# Altium schematic sheet Chassis-Rx-PCB(STM32).SchDoc
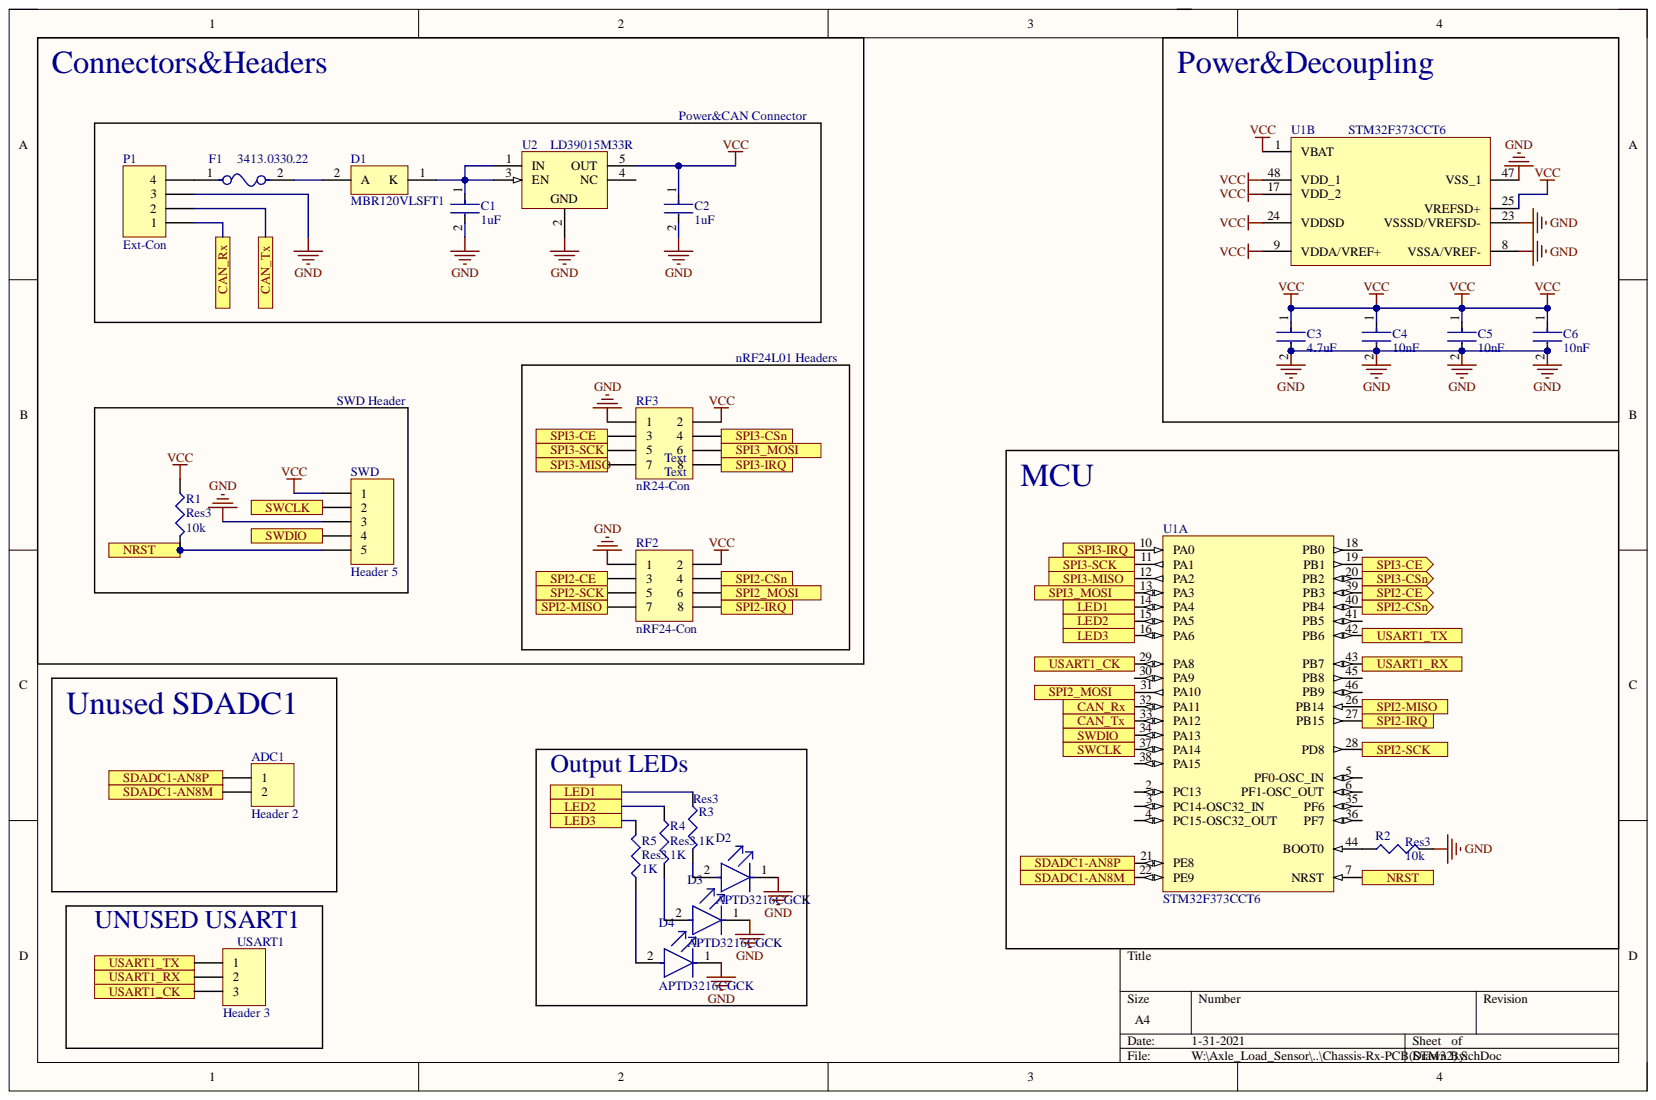
[diagram p0: Chassis-Rx-PCB(STM32).SchDoc]

## Parts
ADC1  Header 2  [HDR1X2]
C1  1uF  [FP-C0805C-DN-MFG]
C2  1uF  [FP-C0805C-DN-MFG]
C3  4.7uF  [FP-C0805C-DN-MFG]
C4  10nF  [FP-C0805C-DN-MFG]
C5  10nF  [FP-C0805C-DN-MFG]
C6  10nF  [FP-C0805C-DN-MFG]
D1  MBR120VLSFT1  [ONSC-SOD-123FL-2-498-01_V]
D2  APTD3216CGCK  [FP-APTD3216CGCK-MFG]
D3  APTD3216CGCK  [FP-APTD3216CGCK-MFG]
D4  APTD3216CGCK  [FP-APTD3216CGCK-MFG]
F1  3413.0330.22  [FP-3413_0330_22-MFG]
P1  Ext-Con  [HDR1X4H]
R1  Res3  value 10k  [J1-0603]
R2  Res3  value 10k  [J1-0603]
R3  Res3  value 1K  [J1-0603]
R4  Res3  value 1K  [J1-0603]
R5  Res3  value 1K  [J1-0603]
RF2  nRF24-Con  [HDR2X4]
RF3  nR24-Con  [HDR2X4]
SWD  Header 5  [HDR1X5]
U1  STM32F373CCT6  [STM-LQFP48_L]  (parts A,B)
U2  LD39015M33R  [SOT23-5L_M]
USART1  Header 3  [HDR1X3]

## Ports
CAN_Rx, CAN_Tx, LED1, LED2, LED3, NRST, SDADC1-AN8M, SDADC1-AN8P, SPI2-CE, SPI2-CSn, SPI2-IRQ, SPI2-MISO, SPI2-SCK, SPI2_MOSI, SPI3-CE, SPI3-CSn, SPI3-IRQ, SPI3-MISO, SPI3-SCK, SPI3_MOSI, SWCLK, SWDIO, USART1_CK, USART1_RX, USART1_TX

## Nets
GND: C1.2 C2.2 C3.2 C4.2 C5.2 C6.2 D2.1 D3.1 D4.1 P1.3 R2.2 RF2.1 RF3.1 SWD.3 U1.8(VSSA/VREF-) U1.23(VSSSD/VREFSD-) U1.47(VSS_1) U2.2
VCC: C2.1 C3.1 C4.1 C5.1 C6.1 R1.1 RF2.2 RF3.2 SWD.1 U1.1(VBAT) U1.9(VDDA/VREF+) U1.17(VDD_2) U1.24(VDDSD) U1.25(VREFSD+) U1.48(VDD_1) U2.5(OUT)
CAN_Rx: P1.1 U1.32(PA11) ; port CAN_Rx
CAN_Tx: P1.2 U1.33(PA12) ; port CAN_Tx
LED1: R3.1 U1.14(PA4) ; port LED1
LED2: R4.1 U1.15(PA5) ; port LED2
LED3: R5.2 U1.16(PA6) ; port LED3
NRST: R1.2 SWD.5 U1.7(NRST) ; port NRST
SDADC1-AN8M: ADC1.2 U1.22(PE9) ; port SDADC1-AN8M
SDADC1-AN8P: ADC1.1 U1.21(PE8) ; port SDADC1-AN8P
SPI2-CE: RF2.3 U1.39(PB3) ; port SPI2-CE
SPI2-CSn: RF2.4 U1.40(PB4) ; port SPI2-CSn
SPI2-IRQ: RF2.8 U1.27(PB15) ; port SPI2-IRQ
SPI2-MISO: RF2.7 U1.26(PB14) ; port SPI2-MISO
SPI2-SCK: RF2.5 U1.28(PD8) ; port SPI2-SCK
SPI2_MOSI: RF2.6 U1.31(PA10) ; port SPI2_MOSI
SPI3-CE: RF3.3 U1.19(PB1) ; port SPI3-CE
SPI3-CSn: RF3.4 U1.20(PB2) ; port SPI3-CSn
SPI3-IRQ: RF3.8 U1.10(PA0) ; port SPI3-IRQ
SPI3-MISO: RF3.7 U1.12(PA2) ; port SPI3-MISO
SPI3-SCK: RF3.5 U1.11(PA1) ; port SPI3-SCK
SPI3_MOSI: RF3.6 U1.13(PA3) ; port SPI3_MOSI
SWCLK: SWD.2 U1.37(PA14) ; port SWCLK
SWDIO: SWD.4 U1.34(PA13) ; port SWDIO
USART1_CK: U1.29(PA8) USART1.3 ; port USART1_CK
USART1_RX: U1.43(PB7) USART1.2 ; port USART1_RX
USART1_TX: U1.42(PB6) USART1.1 ; port USART1_TX
NetC1_1: C1.1 D1.1 U2.1(IN) U2.3(EN)
NetD1_2: D1.2 F1.2
NetD2_2: D2.2 R3.2
NetD3_2: D3.2 R4.2
NetD4_2: D4.2 R5.1
NetF1_1: F1.1 P1.4
NetR2_1: R2.1 U1.44(BOOT0)

Not connected: U1 2(PC13) 3(PC14-OSC32_IN) 4(PC15-OSC32_OUT) 5(PF0-OSC_IN) 6(PF1-OSC_OUT) 18(PB0) 30(PA9) 35(PF6) 36(PF7) 38(PA15) 41(PB5) 45(PB8) 46(PB9); U2 4(NC)

## Library data of the parts
ADC1 = Header 2: Header, 2-Pin; Component Kind: Standard; LatestRevisionDate: 17-Jul-2002; LatestRevisionNote: Re-released for DXP Platform.; Library Name: Miscellaneous Connectors.IntLib; Signal Integrity: Connector; Publisher: Altium Limited
C1-C6 = CMP-1036-04552-2: CAP CER 0.22UF 50V X7R 0805; Manufacturer: KEMET; Manufacturer Part Number: C0805C224K5RACTU; Capacitance: 0.22 μF; Material: Ceramic; Temperature Coefficient: 30ppm/°C; Tolerance: 0.1; Value: 0.22 μF; Automotive: No; Category: Cap; Component Kind: Standard; ComponentLink2Description: Datasheet; ComponentLink2URL: https://content.kemet.com/datasheets/KEM_C1003_C0G_SMD.pdf; Device Class L1: Passive Components; Device Class L2: Capacitors; Device Class L3: Ceramic Capacitors; Digikey Description: CAP CER 0.22UF 50V X7R 0805; Height: 0.88mm; Ipc Land Pattern Name: CAPC200125X78; Lead Free: Yes; Library Name: Altium Content Vault; Mouser Description: CAP CER 0.22UF 50V X7R 0805; Octopart: https://octopart.com/c0805c224k5ractu-kemet-144670; Package: C0805C-DN; RoHS: TRUE; Temperature: -55°C to +125°C; Temperature Characteristic: X7R; Temperature Range High: +125°C; Temperature Range Low: -55°C; Voltage Rating: 50 V
D1 = CMP-1055-00448-1: Schottky Power Rectifier, 2-Pin SOD-123, Tape and Reel; Manufacturer: On Semiconductor; Configuration: Single; IFSM Max (A): 45; Component Kind: Standard; ComponentLink1Description: Manufacturer URL; ComponentLink1URL: http://www.onsemi.com/; ComponentLink2Description: Datasheet; ComponentLink2URL: http://www.onsemi.cn/pub_link/Collateral/MBR120VLSFT1-D.PDF; ComponentLink3Description: Package Specification; ComponentLink3URL: http://www.onsemi.cn/pub_link/Collateral/MBR120VLSFT1-D.PDF; DatasheetVersion: Rev. 2; Library Name: Altium Content Vault; Mounting Technology: Surface Mount; PackageDescription: 2-Pin SOD, Body 1.65 x 2.7 mm; PackageReference: SOD-123FL-2-498-01; PackageVersion: Rev. A; Packing: Tape and Reel; PartNumber: MBR120VLSFT1; RoHS: FALSE
D2-D4 = CMP-2000-05792-2: LED GREEN CLEAR 2SMD; Dominant Wavelength: 570nm; Forward Current: 20mA; Forward Voltage: 2.1V; Luminous Intensity: 300mcd; Number of LEDs: 1; Viewing Angle: 50°; Case/Package: 1206; Case Code (Imperial): 1206; Case Code (Metric): 3216; Color: Green; Component Kind: Standard; Height: 1.8mm; Illumination Color: Green; Lead Free: Lead Free; Length: 3.2mm; Lens Color: Clear; Lens Style: Clear; Library Name: Altium Content Vault; Max Operating Temperature: 85°C; Min Operating Temperature: -40°C; Mount: Surface Mount; Number of Pins: 2; Package Quantity: 2000; Packaging: Tape and Reel; Test Current: 20mA; Width: 1.6mm
F1 = CMP-26293-000001-1: FUSE BOARD MNT 15A 32VAC 63VDC; Manufacturer: Schurter Inc; Fuse Blow Speed: Slow; Fuse I2T: 65A2Sec; Fuse Interrupt Dc Current: 100A; Fuse Voltage Drop: 57mV; Max Fuse Ac Voltage: 32V; Max Fuse Dc Voltage: 63V; Resistance: 3.5mΩ; Value: 3.5mΩ; Ambient Temperature Range High: +90°C; Ambient Temperature Range Low: -55°C; Automotive: No; Category: Fuse; Component Kind: Standard; ComponentLink2Description: Datasheet; ComponentLink2URL: https://us.schurter.com/en/datasheet/typ_UST_1206.pdf; Device Class L1: Circuit Protection; Device Class L2: Fuses; Device Class L3: unset; Digikey Description: FUSE BOARD MNT 15A 32VAC 63VDC; Fuse Current: 15A; Height: 0.6mm; Ipc Land Pattern Name: CAPC320160X60; Lead Free: Yes; Library Name: Altium Content Vault; Manufacturer Part Number: 3413.0330.22; Mouser Description: Surface Mount Fuses UST 1206 FUSE 15A F; Octopart: https://octopart.com/3413.0330.22-schurter-8400836; Package: 0; RoHS: TRUE; Voltage Rating: 63V
P1 = Header 4H: Header, 4-Pin, Right Angle; Component Kind: Standard; LatestRevisionDate: 17-Jul-2002; LatestRevisionNote: Re-released for DXP Platform.; Library Name: Miscellaneous Connectors.IntLib; Signal Integrity: Connector; Publisher: Altium Limited
R1-R5 = Res3: Resistor; Component Kind: Standard; LatestRevisionDate: 29-May-2009; LatestRevisionNote: IPC-7351 Footprint Added.; Library Name: Miscellaneous Devices.IntLib; PackageDescription: Chip Resistor; PackageReference: J1-0603; Published: 8-Jun-2000; Signal Integrity: Res; Simulation: RESISTOR; Publisher: Altium Limited
RF2 RF3 = Header 4X2: Header, 4-Pin, Dual row; Component Kind: Standard; LatestRevisionDate: 17-Jul-2002; LatestRevisionNote: Re-released for DXP Platform.; Library Name: Miscellaneous Connectors.IntLib; Signal Integrity: Connector; Publisher: Altium Limited
SWD = Header 5: Header, 5-Pin; Component Kind: Standard; LatestRevisionDate: 17-Jul-2002; LatestRevisionNote: Re-released for DXP Platform.; Library Name: Miscellaneous Connectors.IntLib; Signal Integrity: Connector; Publisher: Altium Limited
U1 = CMP-0572-00021-2: ARM Cortex-M4 32-bit MCU, 256 KB Flash, 32 KB Internal RAM, 36 I/Os, 48-pin LQFP, -40 to 85 degC, Tray; FLASH Size (Prog) (kB): 256; I/Os (High Current): 36; Internal RAM Size (kB): 32; Operating Frequency(F) (Processor speed) (MHz): 72; Supply Voltage(Vcc) min (V): 2; Component Kind: Standard; ComponentLink1Description: Manufacturer URL; ComponentLink1URL: http://www.st.com/web/en/home.html; ComponentLink2Description: Datasheet; ComponentLink2URL: http://www.st.com/st-web-ui/static/active/en/resource/technical/document/datasheet/DM00046749.pdf; ComponentLink3Description: Package Specification; ComponentLink3URL: http://www.st.com/st-web-ui/static/active/en/resource/technical/document/datasheet/DM00058181.pdf; Core: ARM Cortex-M4; DatasheetVersion: Rev. 3; Library Name: Altium Content Vault; Mounting Technology: Surface Mount; PackageDescription: 48-Pin Low Profile Quad Flat Package, 7 x 7 mm Body, 0.5 mm Pitch; PackageReference: LQFP48; PackageVersion: Rev. 6, 06/2013; Packing: Tray; PartNumber: STM32F373CCT6
U2 = CMP-0244-00351-1: 150 mA Low Quiescent Current Low Noise Voltage Regulator, 3.3V, 5-Pin SOT-23, Tape and Reel; Component Kind: Standard; ComponentLink1Description: Manufacturer URL; ComponentLink1URL: http://www.st.com; ComponentLink2Description: Datasheet; ComponentLink2URL: http://www.st.com/internet/com/TECHNICAL_RESOURCES/TECHNICAL_LITERATURE/DATASHEET/CD00173477.pdf; DatasheetVersion: 1/02/2009; Library Name: Altium Content Vault; PackageDescription: 5-Pin SOT-23 Package, 2.9 x 1.6 mm Body, 0.95 mm Pitch, 2.8 mm Lead Span; PackageReference: SOT23-5L; PackageVersion: 1/01/2008
USART1 = Header 3: Header, 3-Pin; Component Kind: Standard; LatestRevisionDate: 17-Jul-2002; LatestRevisionNote: Re-released for DXP Platform.; Library Name: Miscellaneous Connectors.IntLib; Signal Integrity: Connector; Publisher: Altium Limited
